# Altium schematic sheet Power.SchDoc
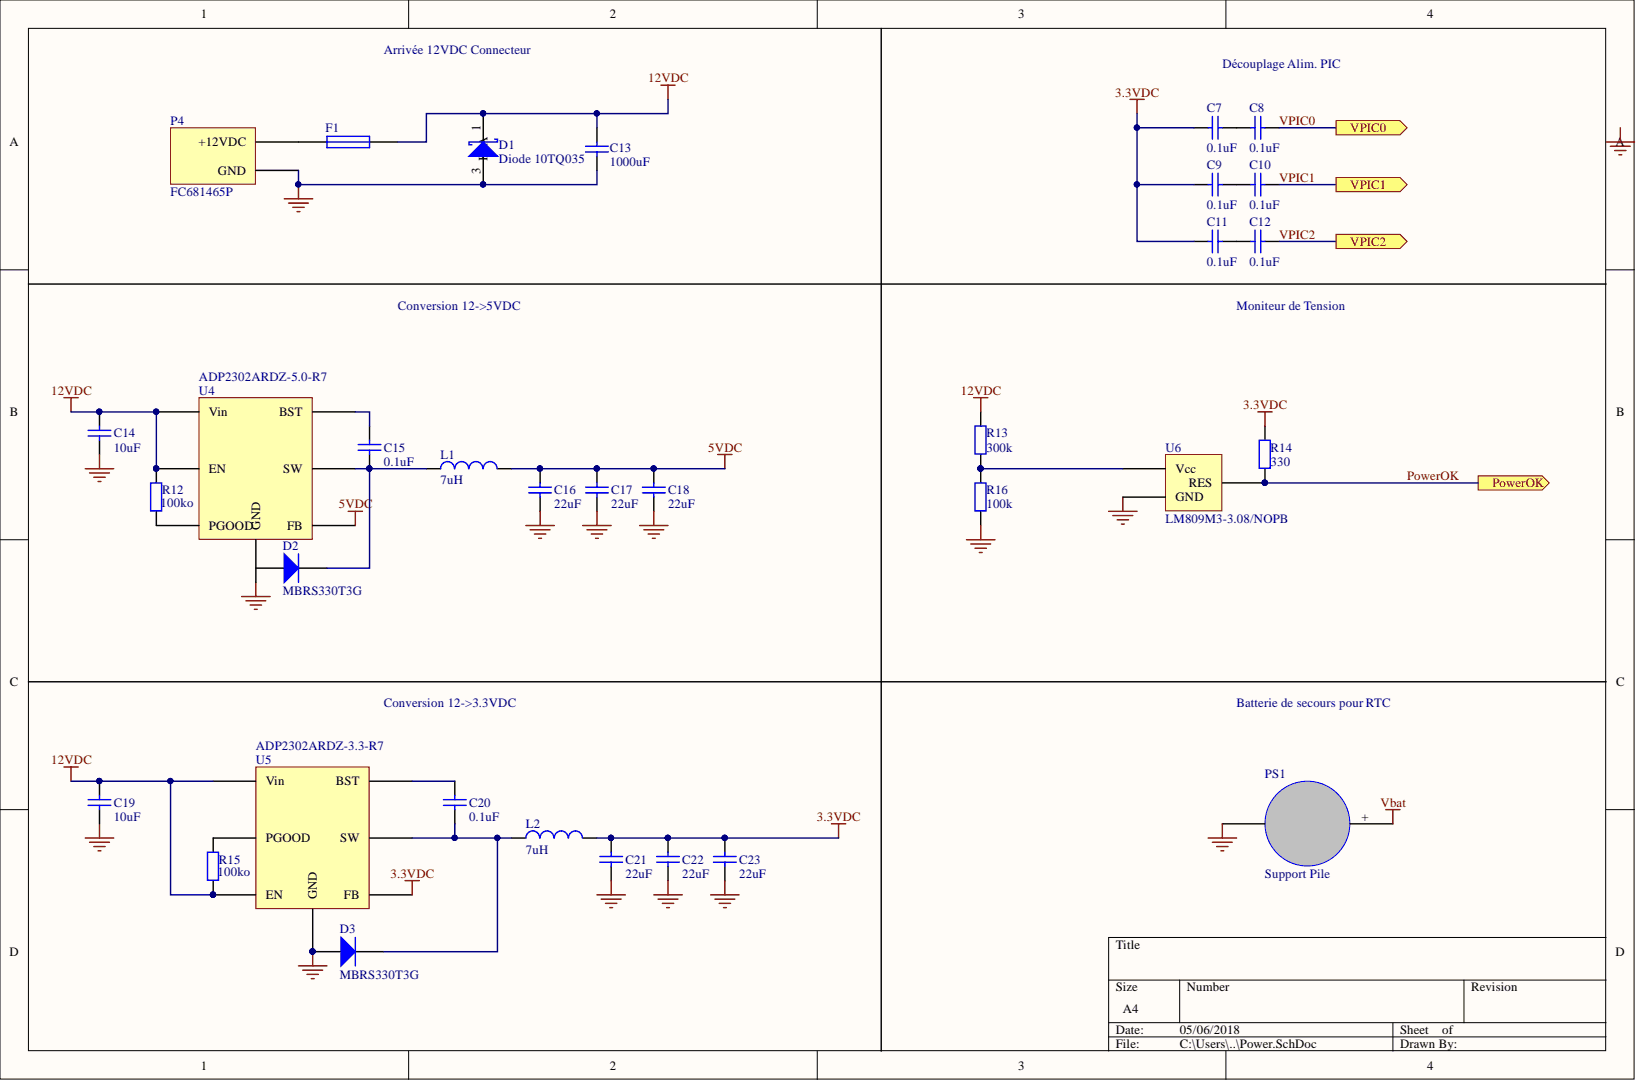
[diagram p0: Power.SchDoc]

## Parts
C7  0.1uF  [0603]
C8  0.1uF  [0603]
C9  0.1uF  [0603]
C10  0.1uF  [0603]
C11  0.1uF  [0603]
C12  0.1uF  [0603]
C13  1000uF  [Condo_trav]
C14  10uF  [0603]
C15  0.1uF  [0603]
C16  22uF  [0603]
C17  22uF  [0603]
C18  22uF  [0603]
C19  10uF  [0603]
C20  0.1uF  [0603]
C21  22uF  [0603]
C22  22uF  [0603]
C23  22uF  [0603]
D1  Diode 10TQ035  [DiodeZin]
D2  MBRS330T3G  [DO214AB SMC]
D3  MBRS330T3G  [DO214AB SMC]
F1  Fuse 1  [FUSIBLE]  (value not drawn)
L1  7uH  [L1L2]
L2  7uH  [L1L2]
P4  FC681465P  [JackAlim]
PS1  Support Pile  [Pile]
R12  100ko  [0603]
R13  300k  [0603]
R14  330  [0603]
R15  100ko  [0603]
R16  100k  [0603]
U4  ADP2302ARDZ-5.0-R7  [converts]
U5  ADP2302ARDZ-3.3-R7  [converts]
U6  LM809M3-3.08/NOPB  [LM809]

## Ports
PowerOK, VPIC0, VPIC1, VPIC2

## Nets
3.3VDC: C7.2 C9.2 C11.2 C21.1 C22.1 C23.1 L2.2 R14.2 U5.5(FB)
5VDC: C16.1 C17.1 C18.1 L1.2 U4.5(FB)
12VDC: C13.2 C14.1 C19.1 D1.1 F1.2 R12.2 R13.2 R15.1 U4.2(Vin) U4.3(EN) U5.2(Vin) U5.3(EN)
GND: C13.1 C14.2 C16.2 C17.2 C18.2 C19.2 C21.2 C22.2 C23.2 D1.3 D3.1 P4.3 PS1.- R16.1 U4.7(GND) U5.7(GND) U6.GND
Vbat: PS1.+
PowerOK: R14.1 U6.RES ; port PowerOK
VPIC0: C8.1 ; port VPIC0
VPIC1: C10.2 ; port VPIC1
VPIC2: C12.1 ; port VPIC2
NetC7_1: C7.1 C8.2
NetC9_1: C9.1 C10.1
NetC11_1: C11.1 C12.2
NetC15_1: C15.1 U4.1(BST)
NetC15_2: C15.2 D2.2 L1.1 U4.8(SW)
NetC20_1: C20.1 U5.1(BST)
NetC20_2: C20.2 D3.2 L2.1 U5.8(SW)
NetF1_1: F1.1 P4.1
NetR12_1: R12.1 U4.4(PGOOD)
NetR13_1: R13.1 R16.2 U6.Vcc
NetR15_2: R15.2 U5.4(PGOOD)

Not connected: D2 1

## Library data of the parts
C7-C12 = Cap: Capacitor; Fabricant: Multicomp; Fournisseur: Farnell; Ref.Fabricant: MCY4C3B104M160CT; Ref.Fournisseur: 2310273; Component Kind: Standard; Library Name: Miscellaneous Devices.IntLib; Signal Integrity: Cap; Simulation: CAP; Value: 0.1uF
C13 = Cap: Capacitor; Fabricant: Multicomp; Fournisseur: Farnell; Ref.Fabricant: MCGLR16V108M10X16; Ref.Fournisseur: 1902972; Component Kind: Standard; Library Name: Miscellaneous Devices.IntLib; Signal Integrity: Cap; Simulation: CAP
C14 = Cap: Cin_Reg_5v; Fabricant: KEMET; Fournisseur: Farnell; Ref.Fabricant: C1210C106K3RACTU; Ref.Fournisseur: 1358512; Component Kind: Standard; Library Name: Miscellaneous Devices.IntLib; Signal Integrity: Cap; Simulation: CAP; Value: 10uF
C15 = Cap: Cbst_Reg_5v; Fabricant: TDK; Fournisseur: Farnell; Ref.Fabricant: CGA2B3X7R1E104K050BB; Ref.Fournisseur: 2210820; Value: 0.1000000001uF; Component Kind: Standard; Library Name: Miscellaneous Devices.IntLib; Signal Integrity: Cap; Simulation: CAP
C16-C18 C21-C23 = Cap: Cout_Reg_3.3v; Fabricant: AVX; Fournisseur: Farnell; Ref.Fabricant: 12103D226KAT2A; Ref.Fournisseur: 1833829; Component Kind: Standard; Library Name: Miscellaneous Devices.IntLib; Signal Integrity: Cap; Simulation: CAP; Value: 22uF
C19 = Cap: Cin_Reg_3v; Fabricant: KEMET; Fournisseur: Farnell; Ref.Fabricant: C1210C106K3RACTU; Ref.Fournisseur: 1358512; Component Kind: Standard; Library Name: Miscellaneous Devices.IntLib; Signal Integrity: Cap; Simulation: CAP; Value: 10uF
C20 = Cap: Cbst_Reg_3.3v; Fabricant: TDK; Fournisseur: Farnell; Ref.Fabricant: CGA2B3X7R1E104K050BB; Ref.Fournisseur: 2210820; Value: 0.10uF; Component Kind: Standard; Library Name: Miscellaneous Devices.IntLib; Signal Integrity: Cap; Simulation: CAP
D1 = Diode 10TQ035: Diode Zenner; Fabricant: ON SEMICONDUCTOR; Fournisseur: Farnell; Ref.Fabricant: MM3Z15VB; Ref.Fournisseur: 2453991; Component Kind: Standard; Library Name: Miscellaneous Devices.IntLib; Simulation: 10TQ035
D2 D3 = Diode: Schottky; Fabricant: OnSemiconductor; Fournisseur: Farnell; Ref.Fournisseur: 1459078; Component Kind: Standard; Library Name: Miscellaneous Devices.IntLib; Ref.Fabricant: MBRS330T3G; Signal Integrity: Diode; Simulation: DIODE
F1 = Fuse 1: Fuse; Fabricant: Littelfuse; Fournisseur: Farnell; Ref.Fabricant: 39515000000; Ref.Fournisseur: 1596504; Component Kind: Standard; Library Name: Miscellaneous Devices.IntLib; Simulation: FUSE
L1 = Inductor: L_Reg_5v; Fabricant: Coilcraft; Fournisseur: Farnell; Ref.Fabricant: MSS1038-702NLC; Ref.Fournisseur: 2288224; Component Kind: Standard; Library Name: Miscellaneous Devices.IntLib; Signal Integrity: Inductor; Simulation: INDUCTOR; Value: 7uH
L2 = Inductor: L_Reg_3.3v; Fabricant: Coilcraft; Fournisseur: Farnell; Ref.Fabricant: MSS1038-702NLC; Ref.Fournisseur: 2288224; Component Kind: Standard; Library Name: Miscellaneous Devices.IntLib; Signal Integrity: Inductor; Simulation: INDUCTOR; Value: 7uH
P4 = FC68148S: Power connector; Fabricant: CLIFF; Fournisseur: Farnell; Ref.Fournisseur: 1854512; Component Kind: Standard; Library Name: Schlib1.SchLib; Ref.Fabricant: FC681465P
PS1 = SMTU-1225-1-LF: Fabricant: Renata; Fournisseur: Farnell; Ref.Fournisseur: 1216353; Component Kind: Standard; Description: Support Pile; Library Name: Schlib1.SchLib; Ref.Fabricant: SMTU-1225-1-LF
R12 R15 = Res2: Resistor; Fabricant: Multicomp; Fournisseur: Farnell; Ref.Fabricant: MCWR06X1003FTL; Ref.Founisseur: 2447226; Component Kind: Standard; Library Name: Miscellaneous Devices.IntLib; Signal Integrity: Res; Simulation: RESISTOR
R13 = Res2: Resistor; Fabricant: MULTICOMP; Fournisseur: Farnell; Ref.Fabricant: MCSR08X3003FTL; Ref.Fournisseur: 2074433; Component Kind: Standard; Library Name: Miscellaneous Devices.IntLib; Signal Integrity: Res; Simulation: RESISTOR; Value: 300k
R14 = Res2: Resistor; Fabricant: TE Connectivity; Fournisseur: Farnell; Ref.Fabricant: RN73C1J232RBTDF; Ref.Fournisseur: 2687973; Component Kind: Standard; Library Name: Miscellaneous Devices.IntLib; Signal Integrity: Res; Simulation: RESISTOR
R16 = Res2: Resistor; Fabricant: MULTICOMP; Fournisseur: Farnell; Ref.Fabricant: MCWR06X1003FTL; Ref.Fournisseur: 2447226; Component Kind: Standard; Library Name: Miscellaneous Devices.IntLib; Signal Integrity: Res; Simulation: RESISTOR; Value: 100k
U4 = ADP2302ARDZ-5.0-R7: Convert. 5v; Fabricant: Analog Devices; Fournisseur: Farnell; Ref.Fournisseur: 2727507; Component Kind: Standard; Library Name: Schlib1.SchLib; Ref.Fabricant: ADP2302ARDZ-5.0-R7
U5 = ADP2302ARDZ-3.3-R7: Convert.3.3; Fabricant: Analog Devices; Fournisseur: Farnell; Ref.Fournisseur: 2376914; Component Kind: Standard; Library Name: Schlib1.SchLib; Ref.Fabricant: ADP2302ARDZ-3.3-R7
U6 = LM809M3-3.08/NOPB: Voltage Monitor; Fabricant: TEXAS INSTRUMENTS; Fournisseur: Farnell; Ref.Fournisseur: 2352609; Component Kind: Standard; Library Name: Schlib1.SchLib; Ref.Fabricant: LM809M3-3.08/NOPB
